# Altium schematic sheet Full_Bridge_Inverter.SchDoc
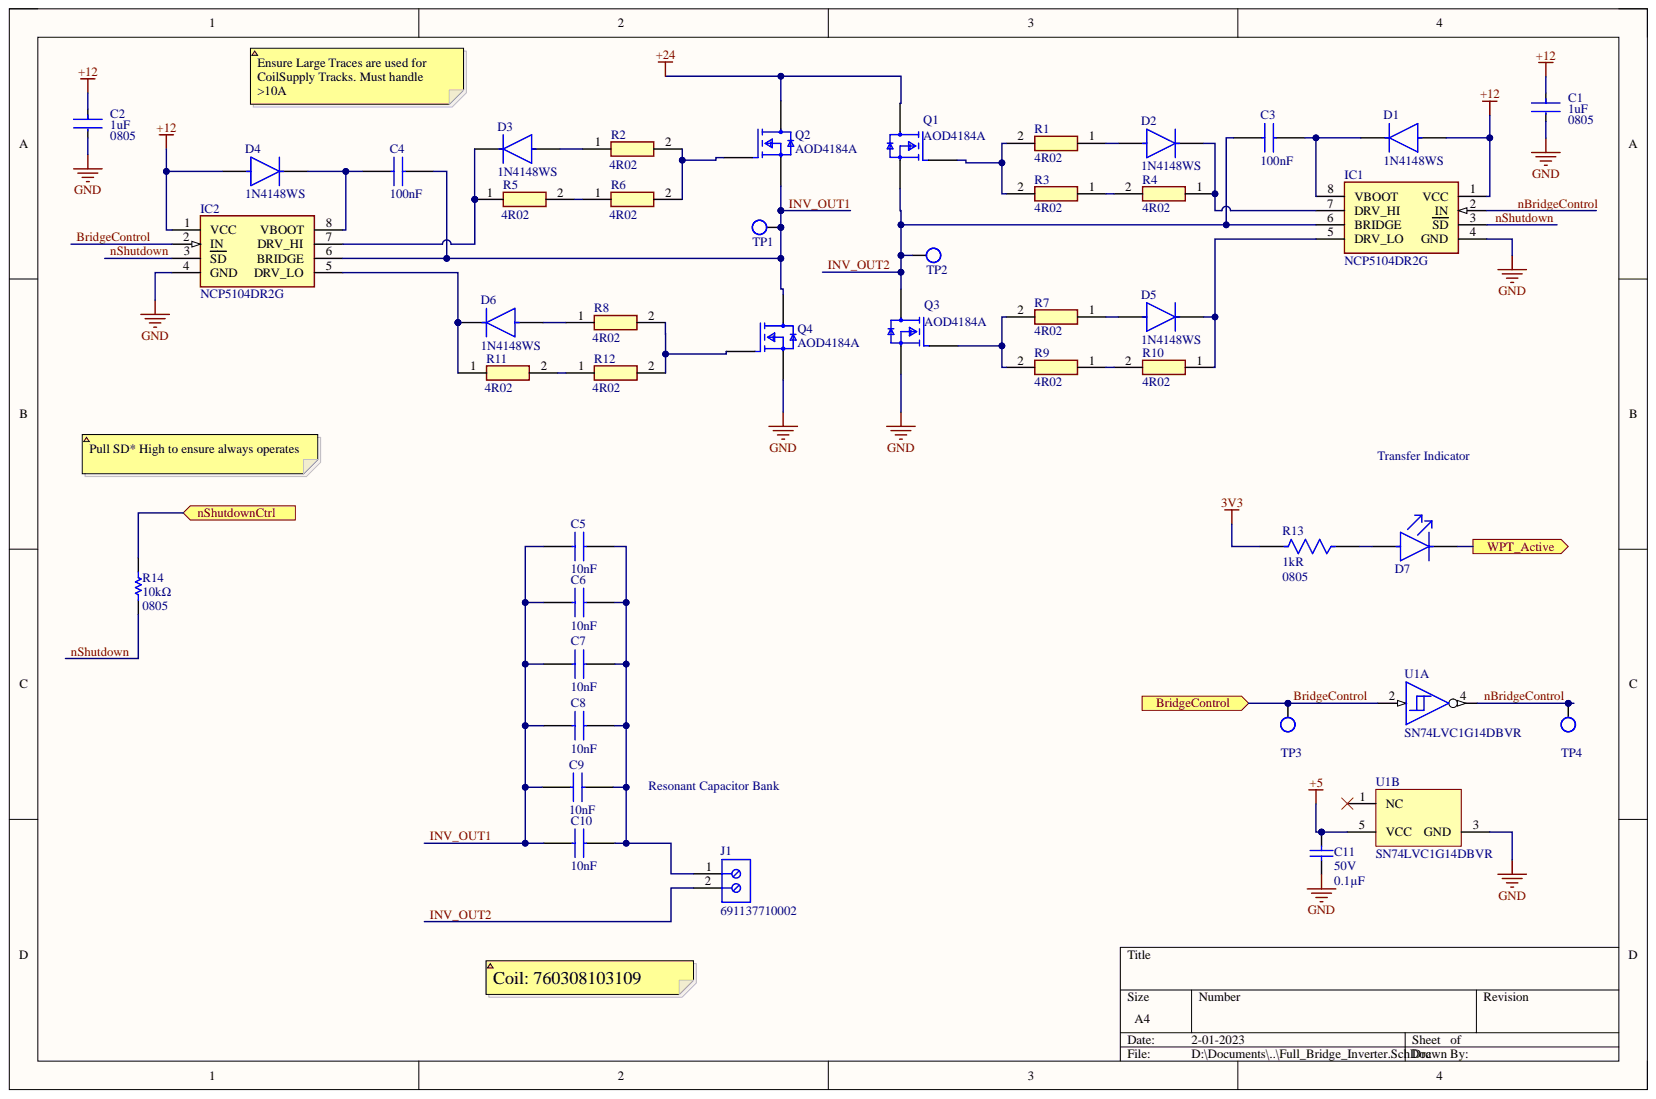
[diagram p0: Full_Bridge_Inverter.SchDoc]

## Parts
C1  Capacitance: 1uF  Case Code (Imperial): 0805  [FP-885012207078-MFG]  (hidden comment: 885012207078)
C2  Capacitance: 1uF  Case Code (Imperial): 0805  [FP-885012207078-MFG]  (hidden comment: 885012207078)
C3  Capacitance: 100nF  [C0805]  (hidden comment: C0805C104K1RACAUTO7210)
C4  Capacitance: 100nF  [C0805]  (hidden comment: C0805C104K1RACAUTO7210)
C5  Capacitance: 10nF  [CAPC3216X110N]  (hidden comment: C1206C103JAGECAUTO)
C6  Capacitance: 10nF  [CAPC3216X110N]  (hidden comment: C1206C103JAGECAUTO)
C7  Capacitance: 10nF  [CAPC3216X110N]  (hidden comment: C1206C103JAGECAUTO)
C8  Capacitance: 10nF  [CAPC3216X110N]  (hidden comment: C1206C103JAGECAUTO)
C9  Capacitance: 10nF  [CAPC3216X110N]  (hidden comment: C1206C103JAGECAUTO)
C10  Capacitance: 10nF  [CAPC3216X110N]  (hidden comment: C1206C103JAGECAUTO)
C11  Capacitance: 0.1µF  Voltage - Rated: 50V  [CAP0805]  (hidden comment: C0805C104K5RACTU)
D1  1N4148WS  [FP-477AB-IPC_C]
D2  1N4148WS  [FP-477AB-IPC_C]
D3  1N4148WS  [FP-477AB-IPC_C]
D4  1N4148WS  [FP-477AB-IPC_C]
D5  1N4148WS  [FP-477AB-IPC_C]
D6  1N4148WS  [FP-477AB-IPC_C]
D7  150060VS75000  [FP-150060VS75000-MFG]  (value not drawn)
IC1  NCP5104DR2G  [SOIC127P600X175-8N]
IC2  NCP5104DR2G  [SOIC127P600X175-8N]
J1  691137710002  [691137710002]
Q1  AOD4184A  [FP-TO252-MFG]
Q2  AOD4184A  [FP-TO252-MFG]
Q3  AOD4184A  [FP-TO252-MFG]
Q4  AOD4184A  [FP-TO252-MFG]
R1  Resistance: 4R02  [RESC3216X70N]  (hidden comment: RMCF1206FT4R02)
R2  Resistance: 4R02  [RESC3216X70N]  (hidden comment: RMCF1206FT4R02)
R3  Resistance: 4R02  [RESC3216X70N]  (hidden comment: RMCF1206FT4R02)
R4  Resistance: 4R02  [RESC3216X70N]  (hidden comment: RMCF1206FT4R02)
R5  Resistance: 4R02  [RESC3216X70N]  (hidden comment: RMCF1206FT4R02)
R6  Resistance: 4R02  [RESC3216X70N]  (hidden comment: RMCF1206FT4R02)
R7  Resistance: 4R02  [RESC3216X70N]  (hidden comment: RMCF1206FT4R02)
R8  Resistance: 4R02  [RESC3216X70N]  (hidden comment: RMCF1206FT4R02)
R9  Resistance: 4R02  [RESC3216X70N]  (hidden comment: RMCF1206FT4R02)
R10  Resistance: 4R02  [RESC3216X70N]  (hidden comment: RMCF1206FT4R02)
R11  Resistance: 4R02  [RESC3216X70N]  (hidden comment: RMCF1206FT4R02)
R12  Resistance: 4R02  [RESC3216X70N]  (hidden comment: RMCF1206FT4R02)
R13  Case Code (Imperial): 0805  Resistance: 1kR  [FP-RNCP0805-MFG]  (hidden comment: RNCP0805FTD1K00)
R14  Package / Case: 0805  Resistance: 10kΩ  [RES0805]  (hidden comment: RC0805FR-0710KL)
TP1  Bridge 1  [TESTPOINT_LOOP]  (value not drawn)
TP2  Bridge 2  [TESTPOINT_LOOP]  (value not drawn)
TP3  Oscillator Out  [TESTPOINT_LOOP]  (value not drawn)
TP4  NOT Oscillator Out  [TESTPOINT_LOOP]  (value not drawn)
U1  SN74LVC1G14DBVR  [DBV0005A_L]  (parts A,B)

## Ports
BridgeControl, nShutdownCtrl, WPT_Active

## Nets
3V3: R13.2
+5: C11.2 U1.5(VCC)
+12: C1.1 C2.1 D1.2 D4.2 IC1.1(VCC) IC2.1(VCC)
+24: Q1.4 Q2.4
GND: C1.2 C2.2 C11.1 IC1.4(GND) IC2.4(GND) Q3.3 Q4.3 U1.3(GND)
BridgeControl: IC2.2(IN) TP3.1 U1.2 ; port BridgeControl
INV_OUT1: C4.2 C5.1 C6.1 C7.1 C8.2 C9.2 C10.2 IC2.6(BRIDGE) Q2.3 Q4.4 TP1.1
INV_OUT2: C3.1 IC1.6(BRIDGE) J1.2 Q1.3 Q3.4 TP2.1
nBridgeControl: IC1.2(IN) TP4.1 U1.4
nShutdown: IC1.3(SD) IC2.3(SD) R14.1
nShutdownCtrl: R14.2 ; port nShutdownCtrl
WPT_Active: D7.1 ; port WPT_Active
NetC3_2: C3.2 D1.1 IC1.8(VBOOT)
NetC4_1: C4.1 D4.1 IC2.8(VBOOT)
NetC5_2: C5.2 C6.2 C7.2 C8.1 C9.1 C10.1 J1.1
NetD2_1: D2.1 IC1.7(DRV_HI) R4.1
NetD2_2: D2.2 R1.1
NetD3_1: D3.1 IC2.7(DRV_HI) R5.1
NetD3_2: D3.2 R2.1
NetD5_1: D5.1 IC1.5(DRV_LO) R10.1
NetD5_2: D5.2 R7.1
NetD6_1: D6.1 IC2.5(DRV_LO) R11.1
NetD6_2: D6.2 R8.1
NetD7_2: D7.2 R13.1
NetQ1_1: Q1.1 R1.2 R3.2
NetQ2_1: Q2.1 R2.2 R6.2
NetQ3_1: Q3.1 R7.2 R9.2
NetQ4_1: Q4.1 R8.2 R12.2
NetR3_1: R3.1 R4.2
NetR5_2: R5.2 R6.1
NetR9_1: R9.1 R10.2
NetR11_2: R11.2 R12.1

Not connected: U1 1(NC)

## Library data of the parts
C1 C2 = CMP-207839-000010-1: CAP CER 1UF 25V X7R 0805; Tolerance: 10%; Case Code (Metric): 2012; Case/Package: 0805; Component Kind: Standard; Dielectric: X7R; Length: 0.079inch; Library Name: Altium Content Vault; Max Operating Temperature: 125°C; Min Operating Temperature: -55°C; Mount: Surface Mount; Packaging: Cut Tape; RoHS Compliant: Yes; Series: WCAP-CSGP; Thickness: 0.053inch; Voltage Rating: 25V; Voltage Rating (DC): 25V; Width: 0.049inch
C3 C4 = C0805C104K1RACAUTO7210: Capacitor; Manufacturer_Name: KEMET; Arrow Part Number: C0805C104K1RACAUTO7210; Arrow Price/Stock: https://www.arrow.com/en/products/c0805c104k1racauto7210/kemet-corporation?region=europe; Component Kind: Standard; Datasheet Link: https://content.kemet.com/datasheets/KEM_C1023_X7R_AUTO_SMD.pdf; Height: 1.1mm; Manufacturer_Part_Number: C0805C104K1RACAUTO7210; Mouser Part Number: 80-C0805C104K1RAUTLR; Mouser Price/Stock: https://www.mouser.co.uk/ProductDetail/KEMET/C0805C104K1RACAUTO7210?qs=NJR6qtu0rR34GE7CYHVK6Q%3D%3D; Library Name: WPT_Transmitter_lib.SchLib
C5-C10 = C1206C103JAGECAUTO: Capacitor; Manufacturer_Name: KEMET; Arrow Part Number: C1206C103JAGECAUTO; Arrow Price/Stock: https://www.arrow.com/en/products/c1206c103jagecauto/kemet-corporation?region=nac; Component Kind: Standard; Datasheet Link: https://content.kemet.com/datasheets/KEM_C1091_C0G_ESD.pdf; Height: 1.1mm; Manufacturer_Part_Number: C1206C103JAGECAUTO; Mouser Part Number: 80-C1206C103JAGECAUT; Mouser Price/Stock: https://www.mouser.co.uk/ProductDetail/KEMET/C1206C103JAGECAUTO?qs=MLItCLRbWsxJM3Q8yxQv7A%3D%3D
C11 = C0805C104K5RACTU: CAP CER 0.1UF 50V X7R 0805; Tolerance: ±10%; Component Kind: Standard; Library Name: Capacitors.IntLib; Package / Case: 0805; Supplier 1: Digi-Key; Supplier Part Number 1: 399-1170-1-ND; Temperature Coefficient: X7R
D1-D6 = CMP-07163-000040-1: DIODE GEN PURP 75V 150MA SOD323F; Element Configuration: Single; Forward Voltage: 1V; Max Forward Surge Current (Ifsm): 2A; Peak Reverse Current: 5uA; Power Dissipation: 200mW; Recovery Time: 4ns; Average Rectified Current: 150mA; Component Kind: Standard; Contact Plating: Tin; Forward Current: 150mA; Height: 1mm; Lead Free: Lead Free; Length: 1.8mm; Library Name: Altium Content Vault; Max Operating Temperature: 150°C; Max Repetitive Reverse Voltage (Vrrm): 75V; Max Reverse Voltage (DC): 75V; Min Operating Temperature: -55°C; Mount: Surface Mount; Number of Pins: 2; Package Quantity: 1; Packaging: Tape and Reel; Radiation Hardening: No; REACH SVHC: No SVHC; Reverse Recovery Time: 4ns; Reverse Voltage: 75V; Weight: 0.0276g; Width: 1.35mm
D7 = CMP-1426-00006-5: LED GREEN CLEAR 0603 SMD; Dominant Wavelength: 570nm; Forward Current: 20mA; Forward Voltage: 2V; Lens Color: Colorless; Lens Size: 0.7mm; Lens Style: Rectangular; Luminous Intensity: 40mcd; Power Rating: 72mW; Reverse Voltage (DC): 5V; Viewing Angle: 140°; Case Code (Imperial): 0603; Case Code (Metric): 1608; Case/Package: 0603; Color: Green; Component Kind: Standard; Height: 0.8mm; Illumination Color: Green; Length: 1.6mm; Lens Transparency: Clear; Library Name: Altium Content Vault; Max Operating Temperature: 85°C; Min Operating Temperature: -40°C; Mount: Surface Mount; Number of Pins: 2; Package Quantity: 4000; Packaging: Cut Tape; RoHS Compliant: Yes; Series: WL-SMCW; Test Current: 20mA; Wavelength: 570nm; Weight: 0.000882oz; Width: 0.8mm
IC1 IC2 = NCP5104DR2G: Integrated Circuit; Manufacturer_Name: onsemi; Arrow Part Number: NCP5104DR2G; Arrow Price/Stock: https://www.arrow.com/en/products/ncp5104dr2g/on-semiconductor; Component Kind: Standard; Datasheet Link: http://www.onsemi.com/pub/Collateral/NCP5104-D.PDF; Height: 1.75mm; Manufacturer_Part_Number: NCP5104DR2G; Mouser Part Number: 863-NCP5104DR2G; Mouser Price/Stock: https://www.mouser.co.uk/ProductDetail/onsemi/NCP5104DR2G?qs=t2BMfLoP1KTbDonJSvc7wQ%3D%3D; Library Name: WPT_Transmitter_lib.SchLib
J1 = CMP-1502-03324-1: Series 1377 - 5.00 mm Horizontal Entry with Pressure Clamp WR-TBL, 2 pin; Manufacturer: Wurth Elektronik; Pin Number: 2; Pitch: 5.00mm; Component Kind: Standard; Datasheet URL: http://katalog.we-online.de/pbs/datasheet/691137710002.pdf; Library Name: Altium Content Vault; Manufacturer URL: http://www.we-online.de; Mounting Technology: THT; Operating Temperature: -30to120degC; Package Reference: 691137710002; Packaging: Box; Part Number: 691137710002; Publisher: WurthElektronik
Q1-Q4 = CMP-83955-000007-1: MOSFET N-CH 40V 50A TO252; Gate to Source Voltage (Vgs): 20V; Input Capacitance: 1.8nF; Max Power Dissipation: 2.3W; Number of Elements: 1; Power Dissipation: 50W; Rds On Max: 7mR; Case/Package: DPAK; Component Kind: Standard; Continuous Drain Current (ID): 50A; Drain to Source Voltage (Vdss): 40V; Library Name: Altium Content Vault; Max Operating Temperature: 175°C; Min Operating Temperature: -55°C; Mount: Surface Mount; Number of Pins: 3; Packaging: Cut Tape; Radiation Hardening: No
R1-R12 = RMCF1206FT4R02: Resistor; Manufacturer_Name: Stackpole Electronics; Arrow Part Number: RMCF1206FT4R02; Arrow Price/Stock: https://www.arrow.com/en/products/rmcf1206ft4r02/stackpole-electronics; Component Kind: Standard; Datasheet Link: https://cs.seielect.com/catalog/SEI-RMCF_RMCP.pdf; Height: 0.7mm; Manufacturer_Part_Number: RMCF1206FT4R02; Library Name: WPT_Transmitter_lib.SchLib
R13 = CMP-26527-001902-1: 1kΩ ±1% 0.25W 0805 High Power Anti-Sulfur Thin Film Chip Resistor; Max Power Dissipation: 250mW; Temperature Coefficient: 100ppm/°C; Case Code (Metric): 2012; Case/Package: 0805; Component Kind: Standard; Features: Moisture Resistant; Height: 0.024inch; Lead Free: Lead Free; Length: 0.079inch; Library Name: Altium Content Vault; Max Operating Temperature: 155°C; Min Operating Temperature: -55°C; Mount: Surface Mount; Number of Terminations: 2; Packaging: Tape and Reel; Power Rating: 250mW; Resistor Type: Thin Film; RoHS Compliant: Yes; Series: RNCP; Size Code: 0805; Tolerance: 1%; Width: 0.049inch
R14 = RC0805FR-0710KL: RES SMD 10K OHM 1% 1/8W 0805; Manufacturer: Yageo; Power (Watts): 0.125W, 1/8W; Temperature Coefficient: ±100ppm/°C; Component Kind: Standard; Composition: Thick Film; Features: Moisture Resistant; Library Name: Resistors.IntLib; Operating Temperature: -55°C ~ 155°C; Supplier 1: Digi-Key; Supplier Part Number 1: 311-10.0KCRCT-ND; Tolerance: ±1%
TP1-TP4 = TESTPOINT_LOOP: PC TEST POINT MINIATURE WHITE; Manufacturer 1: Keystone Electronics; Manufacturer Part Number 1: 5002; Component Kind: Standard; Library Name: Connectors and Headers.IntLib; Mount: Through Hole; Supplier 1: Digi-Key; Supplier Part Number 1: 36-5002-ND
U1 = CMP-0859-00030-4: Single Schmitt-Trigger Inverter, DBV0005A, LARGE T&R; Manufacturer: Texas Instruments; 3-State Output: No; Bits(#): 1; F @ Nom Voltage(Max)(Mhz): 150; ICC @ Nom Voltage(Max)(mA): 0.01; Input Type: CMOS/TTL; Logic: Inverting; Output Drive (IOL/IOH)(Max)(mA): 32/-32; Output Type: CMOS; Rating: Catalog; Schmitt Trigger: Yes; Sub-Family: Inverting Buffer/Driver; Technology Family: LVC; tpd @ Nom Voltage(Max)(ns): 11,6.5,5.5,5; VCC(Max)(V): 5.5; VCC(Min)(V): 1.65; Voltage(Nom)(V): 1.8,2.5,3.3,5; Component Kind: Standard; DatasheetVersion: SCES218W; Generic Part Number: SN74LVC1G14; Library Name: Altium Content Vault; Operating Temperature Range(C): -40 to 125,-40 to 85; PackageReference: DBV0005A
